# Altium schematic sheet General Overview.SchDoc
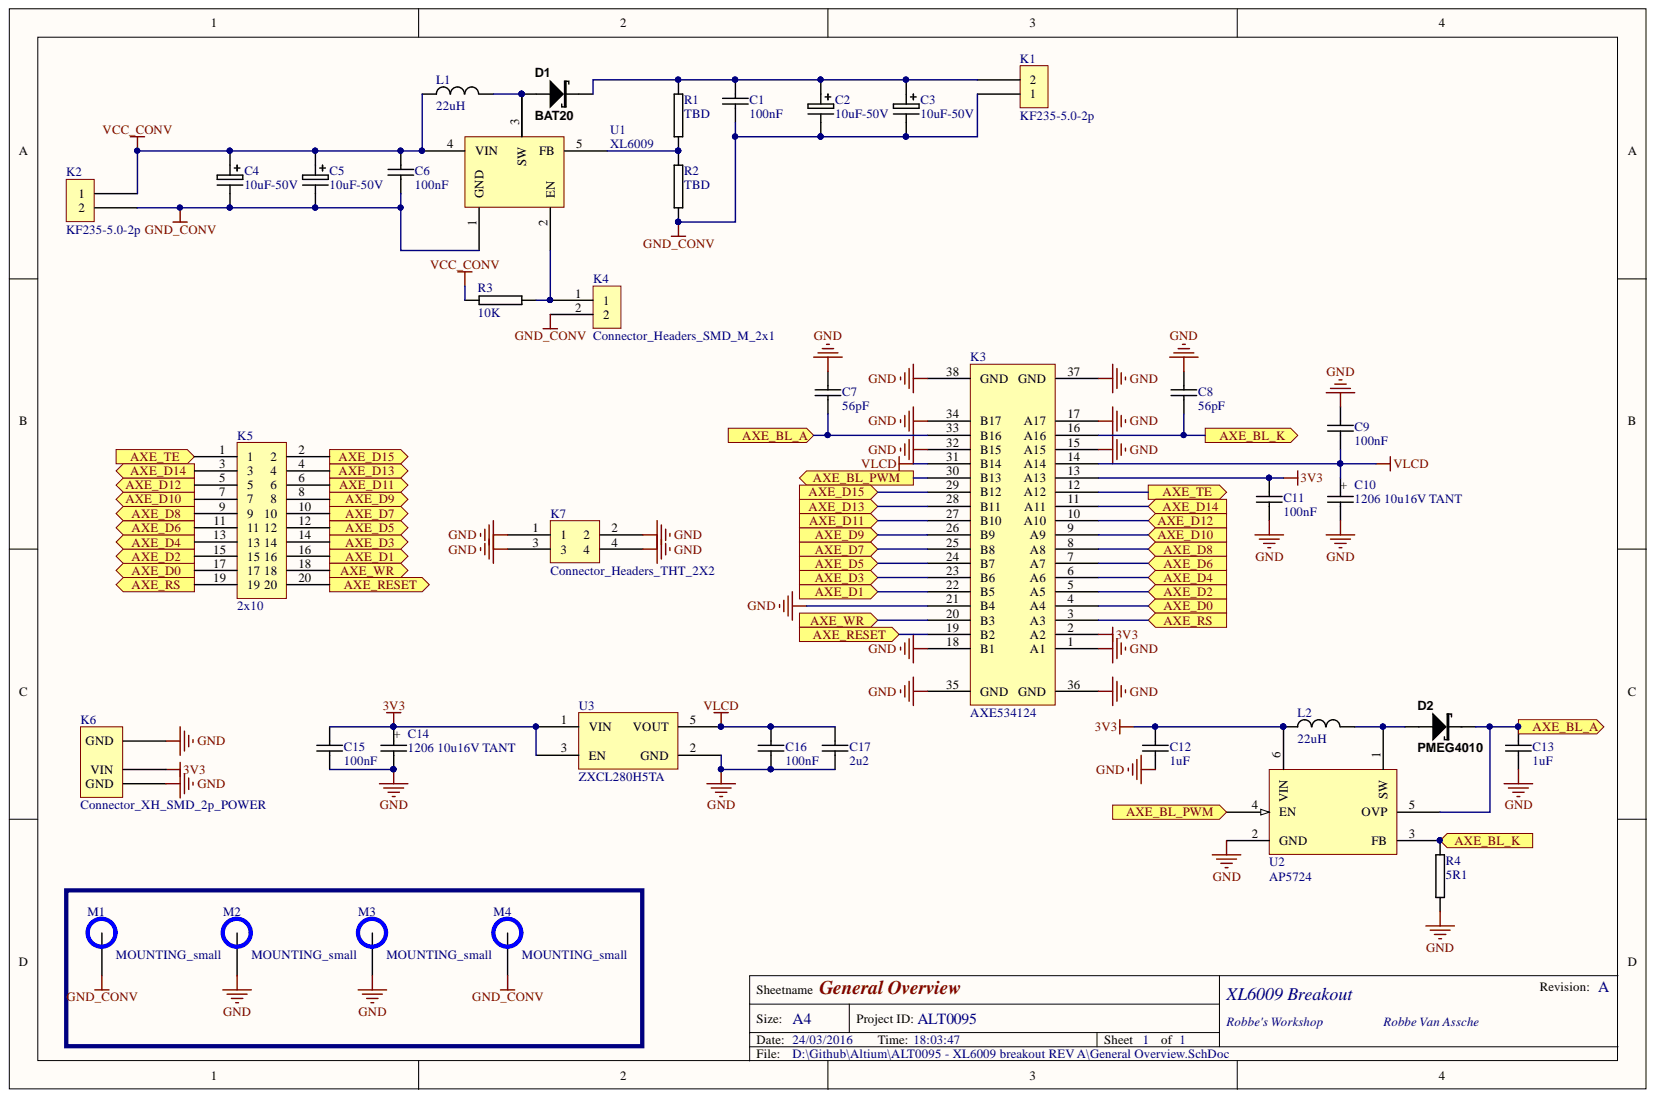
[diagram p0: General Overview.SchDoc]

## Parts
C1  100nF  [0805C-TBD]
C2  10uF-50V  [PANACAP-D-GENERIC]
C3  10uF-50V  [PANACAP-D-GENERIC]
C4  10uF-50V  [PANACAP-D-GENERIC]
C5  10uF-50V  [PANACAP-D-GENERIC]
C6  100nF  [0805C-TBD]
C7  56pF  [0603C-TBD]
C8  56pF  [0603C-TBD]
C9  100nF  [0603C-TBD]
C10  1206 10u16V TANT  [1206C+_TANTAL-10u16V]
C11  100nF  [0603C-TBD]
C12  1uF  [0603C-GENERIC]
C13  1uF  [0603C-GENERIC]
C14  1206 10u16V TANT  [1206C+_TANTAL-10u16V]
C15  100nF  [0603C-GENERIC]
C16  100nF  [0603C-GENERIC]
C17  2u2  [0603C-2U2]
D1  BAT20  [SOD323]
D2  PMEG4010  [SOD323]
K1  KF235-5.0-2p  [KF235-5.0-2p]
K2  KF235-5.0-2p  [KF235-5.0-2p]
K3  AXE534124  [AXE534124]
K4  Connector_Headers_SMD_M_2x1  [Header_Male_2.54 2x1_COMBO]
K5  2x10  [HEADER-2X10]
K6  Connector_XH_SMD_2p_POWER  [2.54_2p]
K7  Connector_Headers_THT_2X2  [HEADER-2X2]
L1  22uH  [CD54]
L2  22uH  [CD54]
M1  MOUNTING_small  [MOUNTING M3 small]
M2  MOUNTING_small  [MOUNTING M3 small]
M3  MOUNTING_small  [MOUNTING M3 small]
M4  MOUNTING_small  [MOUNTING M3 small]
R1  TBD  [0805R-TBD]
R2  TBD  [0805R-TBD]
R3  10K  [0805R-10K]
R4  5R1  [0603R-GENERIC]
U1  XL6009  [TO263-5]
U2  AP5724  [U-DFN2020-6]
U3  ZXCL280H5TA  [SOT65P210X100-5L]

## Ports
AXE_BL_A, AXE_BL_K, AXE_BL_PWM, AXE_D0, AXE_D1, AXE_D2, AXE_D3, AXE_D4, AXE_D5, AXE_D6, AXE_D7, AXE_D8, AXE_D9, AXE_D10, AXE_D11, AXE_D12, AXE_D13, AXE_D14, AXE_D15, AXE_RESET, AXE_RS, AXE_TE, AXE_WR

## Nets
3V3: C11.1 C12.1 C14.1 C15.1 K3.2(A2) K3.13(A13) K6.1 L2.1 U2.6(VIN) U3.1(VIN) U3.3(EN)
GND: C7.1 C8.1 C9.1 C10.2 C11.2 C12.2 C13.2 C14.2 C15.2 C16.2 C17.2 K3.1(A1) K3.15(A15) K3.17(A17) K3.18(B1) K3.21(B4) K3.32(B15) K3.34(B17) K3.35(GND) K3.36(GND) K3.37(GND) K3.38(GND) K6.0 K6.2 K7.1 K7.2 K7.3 K7.4 M2.1 M3.1 R4.2 U2.2(GND) U3.2(GND)
GND_CONV: C1.2 C2.- C3.- C4.- C5.- C6.2 K1.1 K2.2 K4.2 M1.1 M4.1 R2.2 U1.1(GND)
VCC_CONV: C4.+ C5.+ C6.1 K2.1 L1.1 R3.1 U1.4(VIN)
VLCD: C9.2 C10.1 C16.1 C17.1 K3.14(A14) K3.31(B14) U3.5(VOUT)
AXE_BL_A: C7.2 C13.1 D2.K K3.33(B16) U2.5(OVP) ; port AXE_BL_A
AXE_BL_K: C8.2 K3.16(A16) R4.1 U2.3(FB) ; port AXE_BL_K
AXE_BL_PWM: K3.30(B13) U2.4(EN) ; port AXE_BL_PWM
AXE_D0: K3.4(A4) K5.17 ; port AXE_D0
AXE_D1: K3.22(B5) K5.16 ; port AXE_D1
AXE_D2: K3.5(A5) K5.15 ; port AXE_D2
AXE_D3: K3.23(B6) K5.14 ; port AXE_D3
AXE_D4: K3.6(A6) K5.13 ; port AXE_D4
AXE_D5: K3.24(B7) K5.12 ; port AXE_D5
AXE_D6: K3.7(A7) K5.11 ; port AXE_D6
AXE_D7: K3.25(B8) K5.10 ; port AXE_D7
AXE_D8: K3.8(A8) K5.9 ; port AXE_D8
AXE_D9: K3.26(B9) K5.8 ; port AXE_D9
AXE_D10: K3.9(A9) K5.7 ; port AXE_D10
AXE_D11: K3.27(B10) K5.6 ; port AXE_D11
AXE_D12: K3.10(A10) K5.5 ; port AXE_D12
AXE_D13: K3.28(B11) K5.4 ; port AXE_D13
AXE_D14: K3.11(A11) K5.3 ; port AXE_D14
AXE_D15: K3.29(B12) K5.2 ; port AXE_D15
AXE_RESET: K3.19(B2) K5.20 ; port AXE_RESET
AXE_RS: K3.3(A3) K5.19 ; port AXE_RS
AXE_TE: K3.12(A12) K5.1 ; port AXE_TE
AXE_WR: K3.20(B3) K5.18 ; port AXE_WR
NetC1_1: C1.1 C2.+ C3.+ D1.K K1.2 R1.1
NetD1_A: D1.A L1.2 U1.3(SW) U1.TAB
NetD2_A: D2.A L2.2 U2.1(SW)
NetK4_1: K4.1 R3.2 U1.2(EN)
NetR1_2: R1.2 R2.1 U1.5(FB)

## Library data of the parts
C1 C6 = Passives_Capacitors_0805_100nF-25V-X7R-MUR: CAPACITOR 0805 CERAMIC; Component Kind: Standard; Supplier 1: Digi-Key; Supplier Part Number 1: 490-1673-1-ND; Library Name: RVA_Passives_Capacitors_0805.SchLib
C2-C5 = Passives_Capacitors_Elco_SMD_PANACAP-10UF-50V-FK: GENERIC CAPACITOR POLARISED; Component Kind: Standard; Supplier 1: Digi-Key; Supplier Part Number 1: PCE3807TR-ND; Library Name: RVA_Passives_Capacitors_Elco_SMD.SchLib
C7-C9 C11 = Passives_Capacitors_0603_TBD: CAPACITOR 0603 CERAMIC VALUE TO BE DETERMINED; Component Kind: Standard; Library Name: RVA_Passives_Capacitors_0603.SchLib
C10 C14 = Passives_Capacitors_Tantalum_1206C-TANTAL SIZE A-10u16V: Component Kind: Standard; Library Name: RVA_Passives_Capacitors_Tantalum.SchLib
C12 C13 = Passives_Capacitors_0603_1UF-25V-X5R-SAM: CAPACITOR 0603 CERAMIC; Reel: C17; Component Kind: Standard; Library Name: RVA_Passives_Capacitors_0603.SchLib
C15 C16 = Passives_Capacitors_0603_100NF-25V-X7R-TDK: CAPACITOR 0603 CERAMIC; Manufacturer: TDK Corporation; Manufacturer Part Number: C1608X7R1E104K; Reel: C12; Component Kind: Standard; Supplier 1: Digi-Key; Supplier Part Number 1: 445-1316-2-ND; Library Name: RVA_Passives_Capacitors_0603.SchLib
C17 = Passives_Capacitors_0603_2U2-6V3-X5R-MUR: CAPACITOR 0603 CERAMIC; Reel: C20; Component Kind: Standard; Library Name: RVA_Passives_Capacitors_0603.SchLib
D1 = BAT20-SOD323: Schottky Diode SOD323 1A 23V; Component Kind: Standard; Supplier 1: Digi-Key; Supplier Part Number 1: 497-3381-2-ND; Library Name: RVA_Silicon Switch_Silicon_Diode_SMD.SchLib
D2 = PMEG4010CEJ: Schottky Diode SOD323 1A 40V; Component Kind: Standard; Library Name: RVA_Silicon Switch_Silicon_Diode_SMD.SchLib
K1 K2 = Connector_Terminal_THT_KF235-5.0-2p: Component Kind: Standard; Library Name: RVA_Connector_Terminal_THT.SchLib
K3 = AXE534124: Sony Vivaz LCD connector; Component Kind: Standard; Library Name: RVA_Connector_LCD_SMD.SchLib
K4 = Connector_Headers_SMD_M_2x1: SMD Header 2x1; Component Kind: Standard; Library Name: RVA_Connector_Headers_SMD.SchLib
K5 = Connector_Headers_THT_2X10: Component Kind: Standard; Library Name: RVA_Connector_Headers_THT.SchLib
L1 L2 = CD54_22uH: CD54; Manufacturer: Vishay/Dale; Manufacturer Part Number: IHLP1616ABER1R0M11; Reel: L18; Component Kind: Standard; Supplier 1: Mouser; Supplier Part Number 1: 70-IHLP1616ABER1R0M1; Library Name: RVA_Passives_Inductors_SMD.SchLib
M1-M4 = MOUNTING_small: MOUNTING HOLE - NON INSTALLABLE; Component Kind: Standard; Library Name: RVA_Mechanical_THT.SchLib
R1 R2 = 0805R-TBD: RESISTOR 0805 VALUE TO BE DETERMINED; Component Kind: Standard; Library Name: RVA_Passives_Resistors_0805.SchLib
R3 = 0805R-10K: RESISTOR 0805 1/4 WATT THIN FILM; Component Kind: Standard; Library Name: RVA_Passives_Resistors_0805.SchLib
R4 = 0603R-5K1: GENERIC RESISTOR 0603; Manufacturer: Stackpole Electronics Inc; Manufacturer Part Number: RMCF 1/16 15K 1% R; Power (Watts): 0.1W, 1/10W; Resistance In Ohms: 15.0K; Temperature Coefficient: ±100ppm/°C; Tolerance: ±1%; Case: 0603 (1608 metric); Category: Resistors; Component Kind: Standard; Composition: Thick Film; Lead Style: Surface Mount (SMD - SMT); Packaging: Tape & Reel (TR); RoHS: RoHS Compliant; Supplier: Digi-Key; Supplier 1: Digi-Key; Supplier Part Number: RMCF1/1615KFRTR-ND; Supplier Part Number 1: RMCF1/1615KFRTR-ND; Library Name: RVA_Passives_Resistors_0603.SchLib
U1 = XL6009: Boost / Buckboost converter; Component Kind: Standard; Library Name: RVA_PSU_BOOST_SMD.SchLib
U2 = AP5724: Backlight LED driver; Component Kind: Standard; Library Name: RVA_LED_Driver.SchLib
U3 = ZXCL280H5TA: 2V8 LDO; Component Kind: Standard; Library Name: RVA_PSU_LDO_SMD.SchLib
K6 = Connector_XH_SMD_2p_POWER: Component Kind: Standard; Library Name: RVA_Connector_XH_SMD.SchLib
K7 = Connector_Headers_THT_2X2: Component Kind: Standard; Library Name: RVA_Connector_Headers_THT.SchLib
